# Altium schematic sheet Sheet2.SchDoc
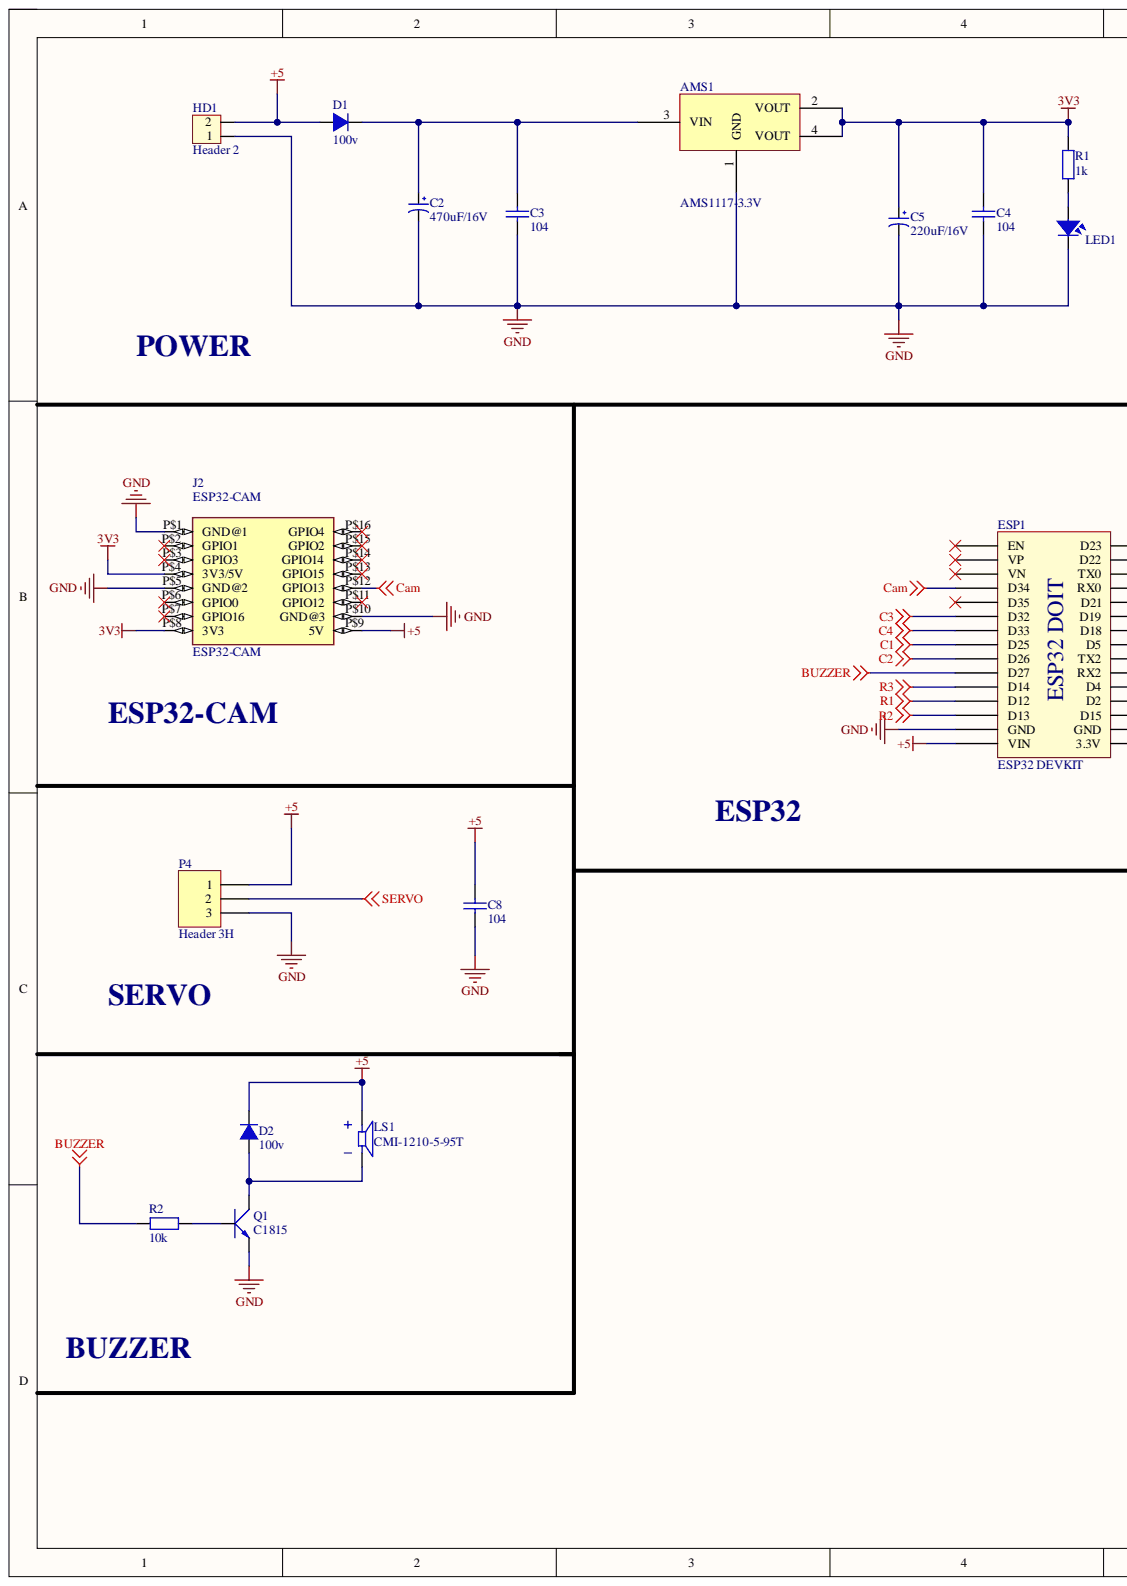
[diagram p0: Sheet2.SchDoc, left]
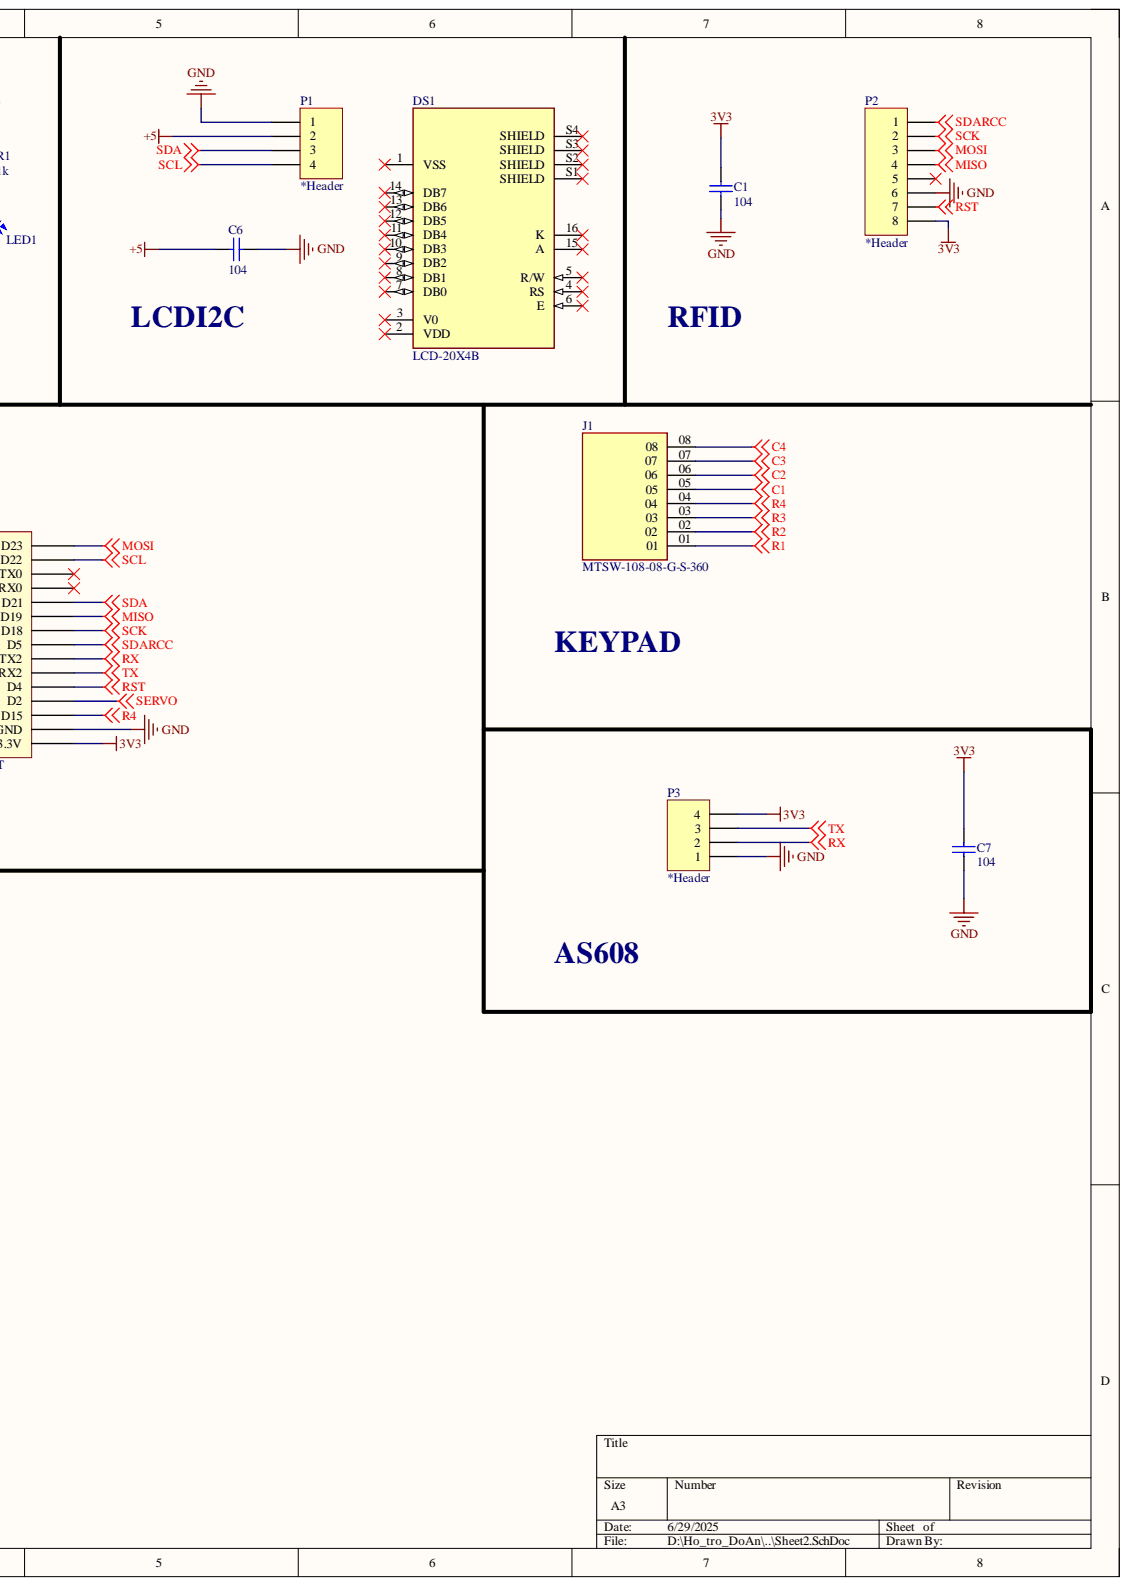
[diagram p1: Sheet2.SchDoc, right]

## Parts
AMS1  AMS1117-3.3V  [SOT223]
C1  104  [tu gom]
C2  470uF/16V  [CP1/2]
C3  104  [tu gom]
C4  104  [tu gom]
C5  220uF/16V  [CP1/2]
C6  104  [tu gom]
C7  104  [tu gom]
C8  104  [tu gom]
D1  100v  [DIODE 1A]
D2  100v  [DIODE 1A]
DS1  LCD-20X4B  [LCD_LCD-20X4B]
ESP1  ESP32 DEVKIT  [ESP32 WROOM 32 KIT]
HD1  Header 2  [HED2]
J1  MTSW-108-08-G-S-360  [SAMTEC_MTSW-108-08-G-S-360]
J2  ESP32-CAM  [ESP32-CAM]
LED1  LED  [LED-5-RED]
LS1  CMI-1210-5-95T  [XDCR_CMI-1210-5-95T]
P1  *Header  [HDR1X4]
P2  *Header  [HDR1X8H]
P3  *Header  [HDR1X4]
P4  Header 3H  [HDR1X3H]
Q1  C1815  [TO92]
R1  1k  [tro vach 1/4w]
R2  10k  [tro vach 1/4w]

## Nets
3V3: AMS1.2(VOUT) AMS1.4(VOUT) C1.1 C4.1 C5.1 C7.1 ESP1.16(3.3V) J2.P$4(3V3/5V) J2.P$8(3V3) P2.8 P3.4 R1.2
+5: C6.1 C8.1 D1.1 D2.2 ESP1.15(VIN) HD1.2 J2.P$9(5V) LS1.P P1.2 P4.1
BUZZER: ESP1.10(D27) R2.1
C1: ESP1.8(D25) J1.05
C2: ESP1.9(D26) J1.06
C3: ESP1.6(D32) J1.07
C4: ESP1.7(D33) J1.08
Cam: ESP1.4(D34) J2.P$12(GPIO13)
GND: AMS1.1(GND) C1.2 C2.2 C3.2 C4.2 C5.2 C6.2 C7.2 C8.2 ESP1.14(GND) ESP1.17(GND) HD1.1 J2.P$1(GND@1) J2.P$5(GND@2) J2.P$10(GND@3) LED1.2 P1.1 P2.6 P3.1 P4.3 Q1.1
MISO: ESP1.25(D19) P2.4
MOSI: ESP1.30(D23) P2.3
R1: ESP1.12(D12) J1.01
R2: ESP1.13(D13) J1.02
R3: ESP1.11(D14) J1.03
R4: ESP1.18(D15) J1.04
RST: ESP1.20(D4) P2.7
RX: ESP1.22(TX2) P3.2
SCK: ESP1.24(D18) P2.2
SCL: ESP1.29(D22) P1.4
SDA: ESP1.26(D21) P1.3
SDARCC: ESP1.23(D5) P2.1
SERVO: ESP1.19(D2) P4.2
TX: ESP1.21(RX2) P3.3
NetAMS1_3: AMS1.3(VIN) C2.1 C3.1 D1.2
NetD2_1: D2.1 LS1.N Q1.2
NetLED1_1: LED1.1 R1.1
NetQ1_3: Q1.3 R2.2

Not connected: DS1 1(VSS) 2(VDD) 3(V0) 4(RS) 5(R/W) 6(E) 7(DB0) 8(DB1) 9(DB2) 10(DB3) 11(DB4) 12(DB5) 13(DB6) 14(DB7) 15(A) 16(K) S1(SHIELD) S2(SHIELD) S3(SHIELD) S4(SHIELD); ESP1 1(EN) 2(VP) 3(VN) 5(D35) 27(RX0) 28(TX0); J2 P$2(GPIO1) P$3(GPIO3) P$6(GPIO0) P$7(GPIO16) P$11(GPIO12) P$13(GPIO15) P$14(GPIO14) P$15(GPIO2) P$16(GPIO4); P2 5

## Library data of the parts
AMS1 = AMS1117 SMD: Dán; Don_Vi: Con; Ma_Hang: MH000596; Value: AMS1117-3.3V SOT223; Chung_Loai: Dán; Component Kind: Standard; Library Name: Altium_Lib_by_KhueNguyenCreator.IntLib
C1 = Tu gom 100 50V: Cắm; Don_Vi: Con; Ma_Hang: MH004765; So_Chan: 2; Ten_Linh_Kien: Tụ gốm 100 10pF 50V; Chung_Loai: Cắm; Component Kind: Standard; Library Name: Altium_Lib_by_KhueNguyenCreator.IntLib
C2 C5 = CP1: Cap P  0,1uF < 22uF , 16 - 50V; Description 1: 10 => 220uF/6,3V/10V - 10uF => 100uF/16V; Description 2: 0,1uF => 33uF/50V - 10uF => 22uF/63V; Description 3: 0,47uF => 10uF/100V; Value: 10uF/50V; Component Kind: Standard; Library Name: VioletofSun.SchLib
C3 C4 C6-C8 = Tu gom 104 50V: Cắm; Don_Vi: Con; Ma_Hang: MH004761; So_Chan: 2; Ten_Linh_Kien: Tụ gốm 104 100nF 50V; Chung_Loai: Cắm; Component Kind: Standard; Library Name: Altium_Lib_by_KhueNguyenCreator.IntLib
D1 D2 = Diode 1N4007: Cắm; Don_Vi: Con; Ma_Hang: MH001063; Ten_Linh_Kien: Diode 1N4007 1A (DIP); Chung_Loai: Cắm; Component Kind: Standard; Library Name: Altium_Lib_by_KhueNguyenCreator.IntLib
DS1 = LCD-20X4B: Display Development Tools 20X4 CHARACTER BLUE LCD W/BACKLIGHT; MF: Gravitech; Availability: In Stock; Check_prices: https://www.snapeda.com/parts/LCD-20X4B/Gravitech/view-part/?ref=eda; Component Kind: Standard; Library Name: LCD-20X4B.IntLib; MP: LCD-20X4B; Package: None; Price: None; Purchase-URL: https://pricing.snapeda.com/search/part/LCD-20X4B/?ref=eda; SnapEDA_Link: https://www.snapeda.com/parts/LCD-20X4B/Gravitech/view-part/?ref=snap
ESP1 = ESP32 WROOM 32 KIT: Component Kind: Standard; Library Name: Altium_Lib_by_KhueNguyenCreator.IntLib
HD1 = Header 2: Header 2 (Green); Component Kind: Standard; Library Name: my Library.SchLib; Value: Header 2
J2 = ESP32-CAM: ESP32 ESP32 Transceiver; 802.11 a/b/g/n (Wi-Fi, WiFi, WLAN), BluetoothÂ® Smart 4.x Low Energy (BLE) Evaluation Board; MF: AI-Thinker; Check_prices: https://www.snapeda.com/parts/ESP32-CAM/AI-Thinker/view-part/?ref=eda; Component Kind: Standard; Library Name: ESP32-CAM.IntLib; MP: ESP32-CAM; Package: None; SnapEDA_Link: https://www.snapeda.com/parts/ESP32-CAM/AI-Thinker/view-part/?ref=snap
LED1 = LED: LED Single colour Green and Red; Value: LED 3 ly Single Colour; Component Kind: Standard; Library Name: LIBRARY.IntLib
LS1 = CMI-1210-5-95T: 12 mm, 5 Vdc, 98 dB, Through Hole, Magnetic Audio Indicator Buzzer; MF: Same Sky; Check_prices: https://www.snapeda.com/parts/CMI-1210-5-95T/Same+Sky/view-part/?ref=eda; Component Kind: Standard; Library Name: CMI-1210-5-95T.IntLib; MP: CMI-1210-5-95T; Package: None; SnapEDA_Link: https://www.snapeda.com/parts/CMI-1210-5-95T/Same+Sky/view-part/?ref=snap
P1 P3 = Header 4: Header, 4-Pin, Right Angle; Component Kind: Standard; LatestRevisionDate: 17-Jul-2002; LatestRevisionNote: Re-released for DXP Platform.; Library Name: Cty1.SchLib; Signal Integrity: Connector; Publisher: Altium Limited
P2 = Header 8H: Header, 8-Pin, Right Angle; Component Kind: Standard; LatestRevisionDate: 17-Jul-2002; LatestRevisionNote: Re-released for DXP Platform.; Library Name: Cty1.SchLib; Signal Integrity: Connector; Publisher: Altium Limited
P4 = Header 3H: Header, 3-Pin, Right Angle; Component Kind: Standard; LatestRevisionDate: 17-Jul-2002; LatestRevisionNote: Re-released for DXP Platform.; Library Name: Devzone_SCHLib.SchLib; Signal Integrity: Connector; Publisher: Altium Limited
Q1 = C1815: NPN transistor; Value: C9014; Component Kind: Standard; Library Name: Altium_Lib_by_KhueNguyenCreator.IntLib
R1 = Tro Vach 1/4W 1% 1.2R: Cắm; Don_Vi: Con; Ma_Hang: MH006341; So_Chan: 2; Ten_Linh_Kien: Trở Vạch 1/4W 1% 1.2R; Chung_Loai: Cắm; Component Kind: Standard; Library Name: Altium_Lib_by_KhueNguyenCreator.IntLib
R2 = Tro Vach 1/4W 5% 10k: Căm; Chung_Loai: Cắm; Don_Vi: Con; Ma_Hang: MH007354; So_Chan: 2; Ten_Linh_Kien: Trở Vạch 1/4w 5% 10K; Component Kind: Standard; Library Name: Altium_Lib_by_KhueNguyenCreator.IntLib
J1 = MTSW-108-08-G-S-360: Availability: Unavailable; Component Kind: Standard; Library Name: MTSW-108-08-G-S-360.IntLib; MP: MTSW-108-08-G-S-360; Package: None; Price: None
